# Altium schematic sheet Stage3B_ref_voltage.SchDoc
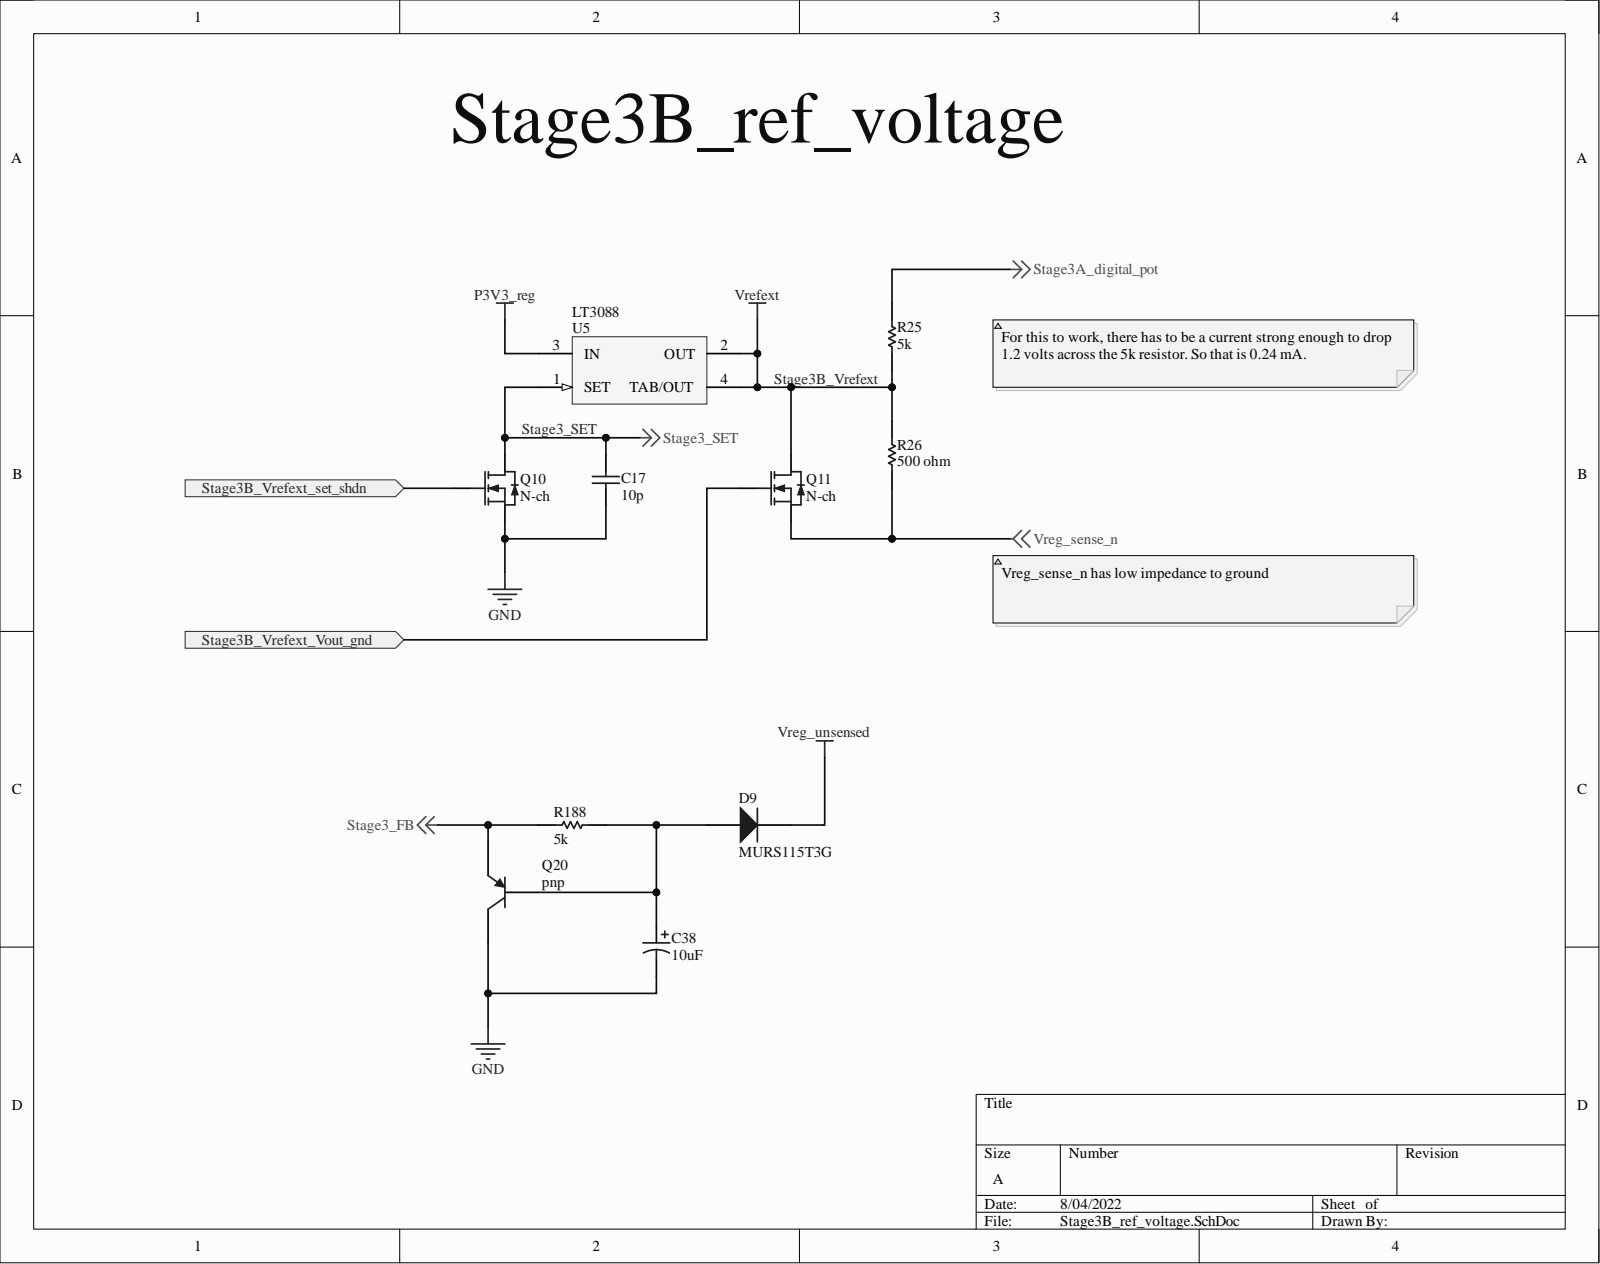
[diagram p0: Stage3B_ref_voltage.SchDoc]

## Parts
C17  10p  [CAPC2013X94X50NL20T25]
C38  10uF  [PCB-45uljczbzgwzo9m7ql45-1]
D9  MURS115T3G  [ONSC-SMB-2-403A-03_V]
Q10  N-ch  [PCB-6ki0nua8twa8bgk8z1dw-1]
Q11  N-ch  [PCB-6ki0nua8twa8bgk8z1dw-1]
Q20  pnp  [PCC-0016-00116-3]
R25  5k  [PCB-uip3f7nxj24hdkeeu2m5-1]
R26  500 ohm  [PCB-9100704-3]
R188  5k  [PCB-uip3f7nxj24hdkeeu2m5-1]
U5  LT3088  [PCB-3oqfx817v31zl7v4z3es-1]

## Ports
Stage3B_Vrefext_set_shdn, Stage3B_Vrefext_Vout_gnd

## Nets
GND: C17.1 C38.2 Q10.2 Q20.3
P3V3_reg: U5.3(IN)
Stage3_FB: Q20.2 R188.2
Stage3A_digital_pot: R25.2
Vreg_sense_n: Q11.2 R26.1
Vreg_unsensed: D9.2
Stage3_SET: C17.2 Q10.3 U5.1(SET)
Stage3B_Vrefext: Q11.3 R25.1 R26.2 U5.2(OUT) U5.4(TAB/OUT)
Stage3B_Vrefext_set_shdn: Q10.1 ; port Stage3B_Vrefext_set_shdn
Stage3B_Vrefext_Vout_gnd: Q11.1 ; port Stage3B_Vrefext_Vout_gnd
NetC38_1: C38.1 D9.1 Q20.1 R188.1

## Library data of the parts
C17 = CMP-128474-2: CAP CER 10PF 100V 5% NP0 0805; Manufacturer Part Number: 08051A100JAT2A; Capacitance: 1e-11 F; Case/Package (SI): 2012; Dielectric Characteristic: C0G/NP0; Dielectric Material: Ceramic Multilayer; Insulation Resistance: 1e+11 Ω; Lead-Free Status: Lead Free; Temperature Coefficient: 0.0 ppm/°C; Voltage Rating (DC): 100.0 V; Capacitance Tolerance: ±5%; Case/Package: 0805; Component Kind: Standard; Library Name: My Personal Space; Mounting Style: Surface Mount; Number of Pins: 2; Octopart Url: https://octopart.com/08051a100jat2a-avx+interconnect+%2F+elco-40099703; OctopartID: de1d1574240c01da; REACH SVHC Compliance: No SVHC; RoHS: Compliant; Size-Height: 0.00071 m; Size-Length: 0.0020066 m; Size-Thickness: 0.0009398 m; Size-Width: 0.00125 m
C38 = CMP-7610bd40367da9d7-6: Capacitor; Aluminum Electrolytic; Fc Radial Elec Cap 10uF 50V; Manufacturer Part Number: EEU-FC1H100L; Capacitance: 10.0 µF; Capacitance Tolerance: ±20%; Lead-Free Status: Lead Free; Voltage Rating (DC): 50.0 V; Component Kind: Standard; Dielectric Material: Aluminum Electrolytic; Library Name: My Personal Space; Lifecycle Status: Not Listed by Manufacturer; Mounting Style: Through Hole; Number of Pins: 2; Octopart URL: https://octopart.com/eeu-fc1h100l-panasonic-39478539; OctopartID: 7610bd40367da9d7; Operating Temperature: 105 °C (max); Packaging: Bulk; REACH SVHC Compliance: No SVHC; RoHS: Compliant; Size-Diameter: 5.00 mm; Size-Height: 11.0 mm; Size-Length: 11.0 mm
D9 = CMP-19360973-4: Surface Mount Ultrafast Power Rectifier, 2-Pin SMB, Pb-Free, Tape and Reel; Current Rating: 1.0 A; Lead-Free Status: Lead Free; Polarity: Standard; Voltage Rating (DC): 150.0 V; Case/Package: SMB; Component Kind: Standard; Halogen Free Status: Halogen Free; Library Name: My Personal Space; Lifecycle Status: Active; Manufacturer Part Number: MURS115T3G; Mounting Style: Surface Mount; Number of Pins: 2; Octopart Url: https://octopart.com/murs115t3g-on+semiconductor-332425; OctopartID: eaf923f6dd16353e; Packaging: Tape; REACH SVHC Compliance: No SVHC; RoHS: Compliant
Q10 Q11 = CMP-bbed7695b02f642a-2: Trans MOSFET N-CH 60V 0.19A 3-Pin TO-236AB T/R; Manufacturer: Nexperia USA Inc.; Manufacturer Part Number: NX138AKR; Lead-Free Status: Lead Free; Case/Package: SOT-23; Component Kind: Standard; Library Name: My Personal Space; Lifecycle Status: Active; Octopart URL: https://octopart.com/nx138akr-nxp+semiconductors-74591908; OctopartID: bbed7695b02f642a; RoHS: Compliant; Supplier: Digi-Key; Supplier Part Number: 1727-2731-2-ND
Q20 = CMP-2558761-7: MMBT3906 Series PNP 40 V 300 mW Small Signal Transistor Surface Mount- SOT-23-3; Manufacturer Part Number: MMBT3906-7-F; Current Rating: -200 mA; Lead-Free Status: Lead Free; Polarity: P-Channel, PNP; Power Dissipation: 300 mW; Voltage Rating (DC): -40.0 V; Case/Package: SOT-23; Component Kind: Standard; Library Name: My Personal Space; Lifecycle Status: Active; Mounting Style: Surface Mount; Number of Pins: 3; Octopart URL: https://octopart.com/mmbt3906-7-f-diodes+inc.-39667659; OctopartID: 7b71b02619dca4b6; Operating Temperature: -55.0 °C to 150 °C; Packaging: Cut Tape (CT); REACH SVHC Compliance: No SVHC; RoHS: Compliant
R25 R188 = CMP-68e4a35bf371b723-1: Res Thick Film 0805 0.82 Ohm 5% 0.25W(1/4W) ±200ppm/C Epoxy SMD Automotive T/R; Manufacturer Part Number: RLP73K2AR82JTD; Case/Package (SI): 2012; ELV Compliant: Compliant; Power Rating: 250 mW; Resistance: 820 mΩ; Case/Package: 0805, 2012; Component Kind: Standard; Library Name: My Personal Space; Lifecycle Status: Not Listed by Manufacturer; Mounting Style: Surface Mount; Octopart URL: http://octopart.com/rlp73k2ar82jtd-te+connectivity-45465331; OctopartID: 68e4a35bf371b723; Packaging: Tape & Reel (TR); REACH SVHC Compliance: No SVHC; Resistance Tolerance: ±5%; RoHS: Compliant
R26 = CMP-9100704-2: PANASONIC   ERJP06F10R0V   RESISTOR, THICK FILM, 10 OHM, 0.5W, 1%; Manufacturer Part Number: ERJ-P06F10R0V; Lead-Free Status: Lead Free; Component Kind: Standard; Library Name: My Personal Space; Lifecycle Status: Not Listed by Manufacturer; Octopart Url: https://octopart.com/erj-p06f10r0v-panasonic-22591554; OctopartID: 60c0a892fde4bf07; RoHS: Compliant
U5 = CMP-83a54925b58a5c62-3: The LT3088 is an 800mA low dropout linear regulator designed for rugged industrial applications. A key feature of the...; Manufacturer Part Number: LT3088EST#PBF; Lead-Free Status: Lead Free; Output Voltage: 34.5 V (max), 0.00 V (min); Component Kind: Standard; Library Name: My Personal Space; Lifecycle Status: Active; Number of Pins: 3; Octopart URL: https://octopart.com/lt3088est%23pbf-linear+technology-59380553; OctopartID: 83a54925b58a5c62; Operating Temperature: -40.0 °C (min); Output Current: 800 mA; REACH SVHC Compliance: No SVHC; RoHS: Compliant
